# Altium schematic sheet 20180616.SchDoc
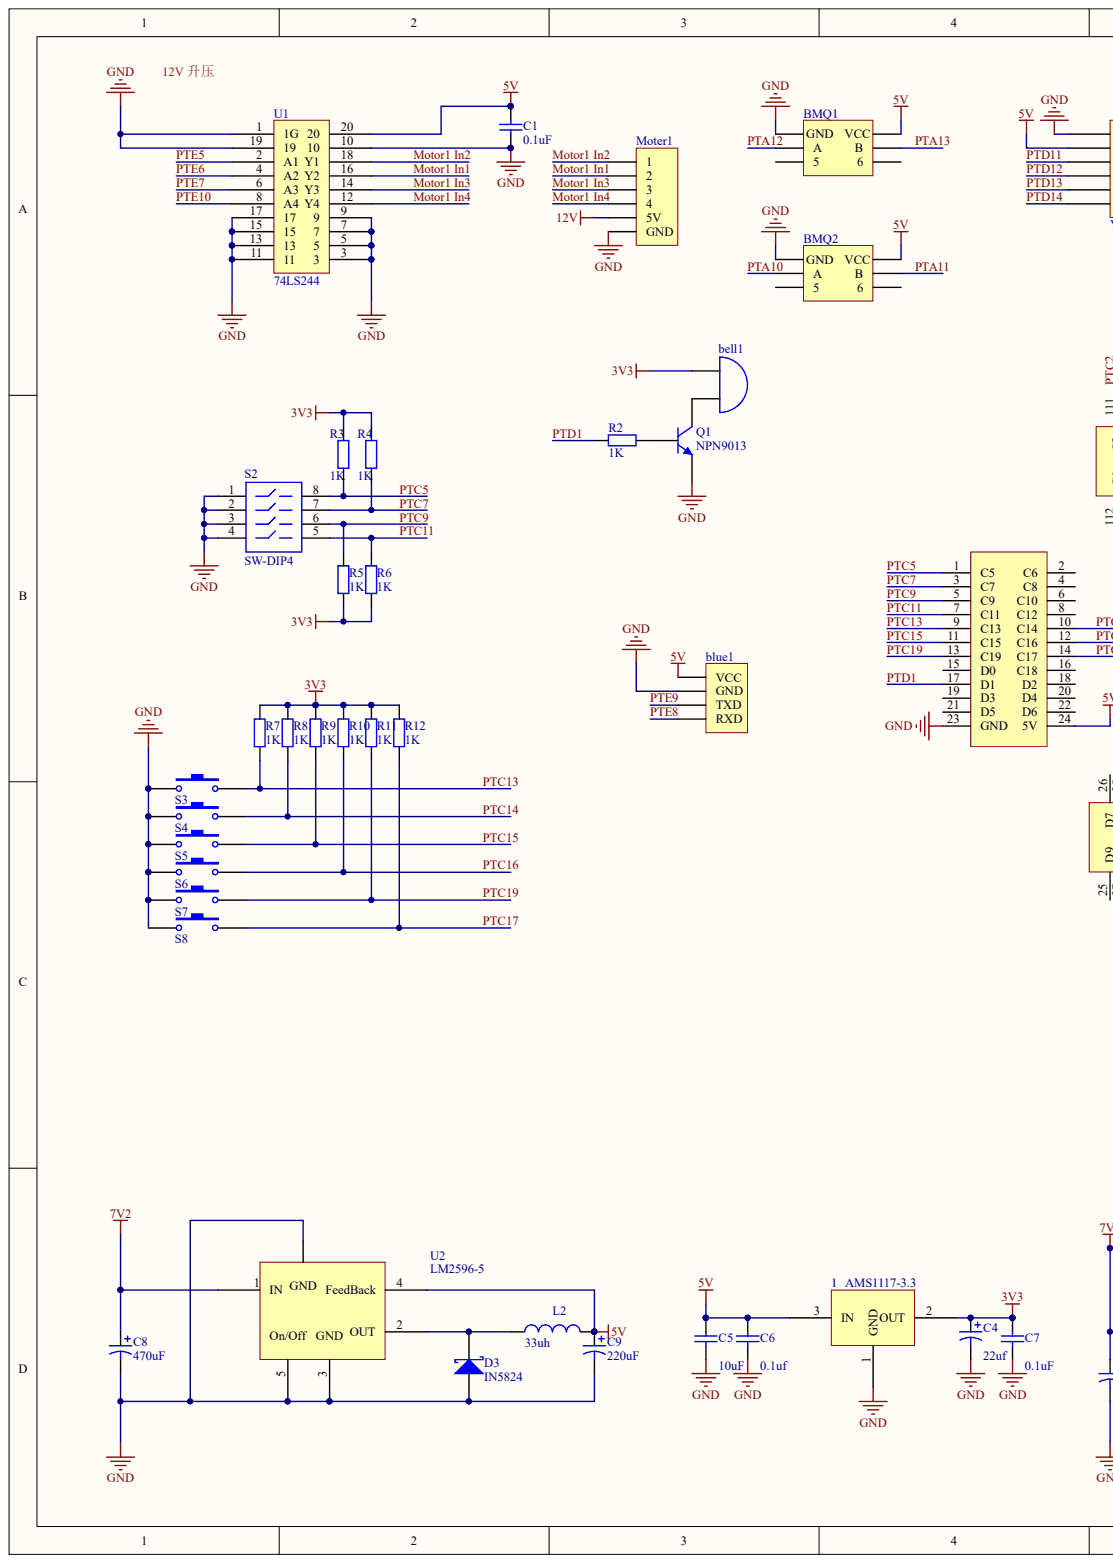
[diagram p0: 20180616.SchDoc, left]
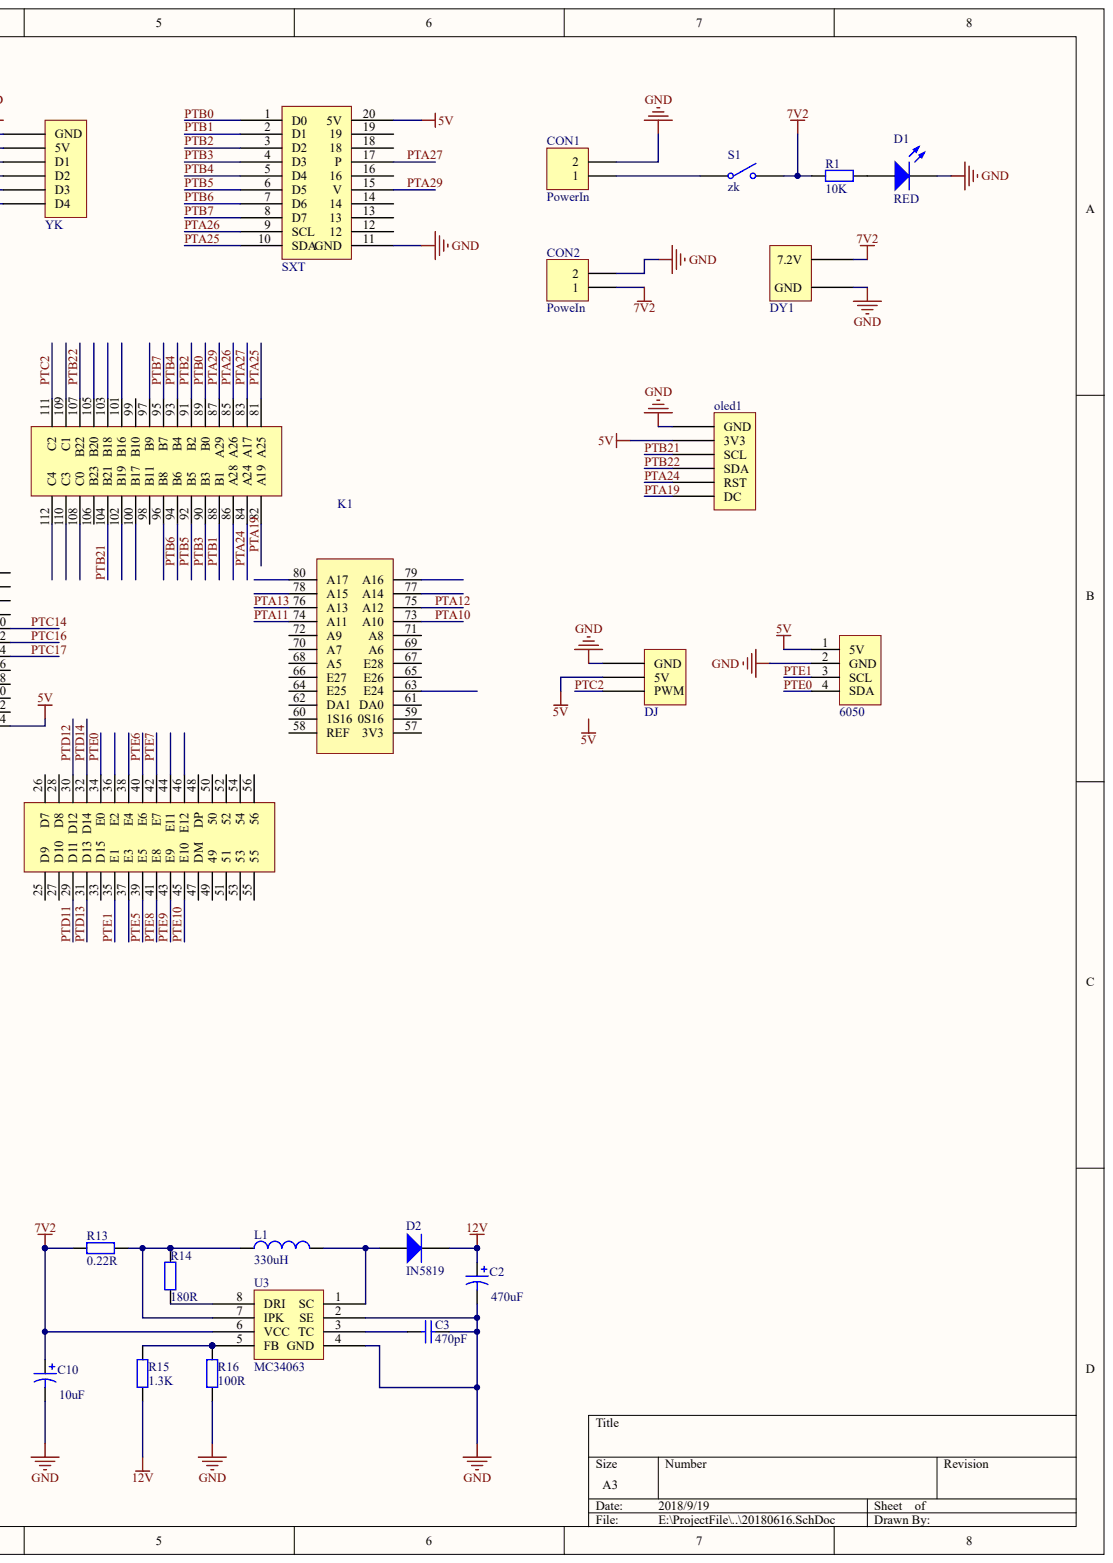
[diagram p1: 20180616.SchDoc, right]

## Parts
1  AMS1117-3.3
*  6050
*1  SXT
*2  YK
*7  DJ
bell1  Bell  (value not drawn)
blue1  blueteeth2  (value not drawn)
BMQ1  encode_1  (value not drawn)
BMQ2  encode_2  (value not drawn)
C1  0.1uF
C2  470uF
C3  470pF
C4  value 22uf  (hidden comment: Cap Pol1)
C5  value 10uF  (hidden comment: Cap)
C6  value 0.1uf  (hidden comment: Cap)
C7  value 0.1uF  (hidden comment: Cap)
C8  value 470uF  (hidden comment: Cap Pol1)
C9  value 220uF  (hidden comment: Cap Pol1)
C10  10uF
CON1  PowerIn
CON2  PoweIn
D1  RED
D2  IN5819
D3  IN5824
DY1  H1  (value not drawn)
K1  K60  (value not drawn)
L1  330uH
L2  value 33uh  (hidden comment: Inductor)
Moter1  Motor
oled1  OLED  (value not drawn)
Q1  NPN9013
R1  value 10K  (hidden comment: Res2)
R2  value 1K  (hidden comment: Res2)
R3  value 1K  (hidden comment: Res2)
R4  value 1K  (hidden comment: Res2)
R5  value 1K  (hidden comment: Res2)
R6  value 1K  (hidden comment: Res2)
R7  value 1K  (hidden comment: Res2)
R8  value 1K  (hidden comment: Res2)
R9  value 1K  (hidden comment: Res2)
R10  value 1K  (hidden comment: Res2)
R11  value 1K  (hidden comment: Res2)
R12  value 1K  (hidden comment: Res2)
R13  0.22R
R14  180R
R15  1.3K
R16  100R
S1  zk
S2  SW-DIP4
S3  SW-PB  (value not drawn)
S4  SW-PB  (value not drawn)
S5  SW-PB  (value not drawn)
S6  SW-PB  (value not drawn)
S7  SW-PB  (value not drawn)
S8  SW-PB  (value not drawn)
U1  74LS244
U2  LM2596-5
U3  MC34063

## Nets
3V3: 1.2 bell1.1 C4.1 C7.2 R3.2 R4.2 R5.2 R6.1 R7.2 R8.2 R9.2 R10.2 R11.2 R12.2
5V: 1.3 *.1(5V) *1.20(5V) *2.2(5V) *7.2 blue1.1(VCC) BMQ1.2(VCC) BMQ2.2(VCC) C1.2 C5.2 C6.2 C9.1 K1.24 L2.2 oled1.2(3V3) U1.20 U2.4(FeedBack)
7V2: C8.1 C10.1 CON2.1 DY1.2 R1.2 R13.2 S1.2 U2.1(IN) U3.6(VCC)
12V: C2.1 D2.2 Moter1.5(5V) R15.2
GND: 1.1 *.2(GND) *1.11(GND) *2.1(GND) *7.1 blue1.2(GND) BMQ1.1(GND) BMQ2.1(GND) C1.1 C2.2 C3.2 C4.2 C5.1 C6.1 C7.1 C8.2 C9.2 C10.2 CON1.2 CON2.2 D1.2 D3.2 DY1.1 K1.23(GND) Moter1.6(GND) oled1.1(GND) Q1.1 R16.2 S2.1 S2.2 S2.3 S2.4 S3.1 S4.1 S5.1 S6.1 S7.1 S8.1 U1.1(1G) U1.3 U1.5 U1.7 U1.9 U1.10 U1.11 U1.13 U1.15 U1.17 U1.19 U2.3 U2.5 U2.6 U3.2(SE) U3.4(GND)
MOTOR1 IN1: Moter1.2 U1.16(Y2)
MOTOR1 IN2: Moter1.1 U1.18(Y1)
MOTOR1 IN3: Moter1.3 U1.14(Y3)
MOTOR1 IN4: Moter1.4 U1.12(Y4)
PTA10: BMQ2.3(A) K1.73(A10)
PTA11: BMQ2.4(B) K1.74
PTA12: BMQ1.3(A) K1.75(A12)
PTA13: BMQ1.4(B) K1.76
PTA19: K1.82 oled1.6(DC)
PTA24: K1.84 oled1.5(RST)
PTA25: *1.10(SDA) K1.81(A25)
PTA26: *1.9(SCL) K1.85(A26)
PTA27: *1.17(P) K1.83(A17)
PTA29: *1.15(V) K1.87(A29)
PTB0: *1.1(D0) K1.89(B0)
PTB1: *1.2(D1) K1.88
PTB2: *1.3(D2) K1.91(B2)
PTB3: *1.4(D3) K1.90
PTB4: *1.5(D4) K1.93(B4)
PTB5: *1.6(D5) K1.92
PTB6: *1.7(D6) K1.94
PTB7: *1.8(D7) K1.95(B7)
PTB21: K1.104 oled1.3(SCL)
PTB22: K1.107(B22) oled1.4(SDA)
PTC2: *7.3 K1.111(C2)
PTC5: K1.1(C5) R3.1 S2.8
PTC7: K1.3(C7) R4.1 S2.7
PTC9: K1.5(C9) R5.1 S2.6
PTC11: K1.7(C11) R6.2 S2.5
PTC13: K1.9(C13) R7.1 S3.2
PTC14: K1.10 R8.1 S4.2
PTC15: K1.11(C15) R9.1 S5.2
PTC16: K1.12 R10.1 S6.2
PTC17: K1.14 R12.1 S8.2
PTC19: K1.13(C19) R11.1 S7.2
PTD1: K1.17(D1) R2.1
PTD11: *2.3(D1) K1.29(D11)
PTD12: *2.4(D2) K1.30
PTD13: *2.5(D3) K1.31(D13)
PTD14: *2.6(D4) K1.32
PTE0: *.4(SDA) K1.34
PTE1: *.3(SCL) K1.35(E1)
PTE5: K1.39(E5) U1.2(A1)
PTE6: K1.40 U1.4(A2)
PTE7: K1.42 U1.6(A3)
PTE8: blue1.4(RXD) K1.41(E8)
PTE9: blue1.3(TXD) K1.43(E9)
PTE10: K1.45(E10) U1.8(A4)
Netbell1_2: bell1.2 Q1.3
NetC3_1: C3.1 U3.3(TC)
NetCON1_1: CON1.1 S1.1
NetD1_1: D1.1 R1.1
NetD2_1: D2.1 L1.2 U3.1(SC)
NetD3_1: D3.1 L2.1 U2.2(OUT)
NetL1_1: L1.1 R13.1 R14.2 U3.7(IPK)
NetQ1_2: Q1.2 R2.2
NetR14_1: R14.1 U3.8(DRI)
NetR15_1: R15.1 R16.1 U3.5(FB)

Not connected: *1 12 13 14 16 18 19; BMQ1 5 6; BMQ2 5 6; K1 2 4 6 8 15(D0) 16 18 19(D3) 20 21(D5) 22 25(D9) 26 27(D10) 28 33(D15) 36 37(E3) 38 44 46 47(DM) 48 49 50 51 52 53 54 55 56 57(3V3) 58 59(0S16) 60 61(DA0) 62 63(E24) 64 65(E26) 66 67(E28) 68 69(A6) 70 71(A8) 72 77(A14) 78 79(A16) 80 86 96 97(B9) 98 99(B10) 100 101(B16) 102 103(B18) 105(B20) 106 108 109(C1) 110 112

## Library data of the parts
L1 L2 = (no symbol name): Code_IEC: 1005; Published: 8-Jun-2000; LatestRevisionDate: 29-May-2009; LatestRevisionNote: IPC-7351 Footprint Added.; PackageReference: 0402-A; PackageDescription: Chip Inductor; Code_JEITA: 0402; Publisher: Altium Limited
  L1: Value: 330uH
1 * *1 *2 *7 bell1 blue1 BMQ1 BMQ2 C1-C10 CON1 CON2 D1-D3 DY1 K1 Moter1 oled1 Q1 R1-R16 S1-S8 U1-U3 = (no symbol name)
  bell1: Published: 24-Mar-1999; LatestRevisionDate: 17-Jul-2002; LatestRevisionNote: Re-released for DXP Platform.; PackageReference: PIN2
  C1: Published: 8-Jun-2000; LatestRevisionDate: 29-May-2009; LatestRevisionNote: IPC-7351 Footprint Added.; PackageReference: C1206; PackageDescription: Chip Capacitor; Value: 0.1uF
  C2: Published: 8-Jun-2000; LatestRevisionDate: 29-May-2009; LatestRevisionNote: IPC-7351 Footprint Added.; PackageReference: C0805; PackageDescription: Chip Capacitor; Value: 470uF
  C3: Published: 8-Jun-2000; LatestRevisionDate: 29-May-2009; LatestRevisionNote: IPC-7351 Footprint Added.; PackageReference: C1206; PackageDescription: Chip Capacitor; Value: 470pF
  C4 C8 C9: Published: 8-Jun-2000; LatestRevisionDate: 17-Jul-2002; LatestRevisionNote: Re-released for DXP Platform.; PackageReference: RB7.6-15; PackageDescription: Polarized Capacitor (Radial); 2 Leads
  C5-C7: Published: 8-Jun-2000; LatestRevisionDate: 17-Jul-2002; LatestRevisionNote: Re-released for DXP Platform.; PackageReference: RAD-0.3; PackageDescription: Radial Cap, Thru-Hole; 2 Leads; 0.3 in Pin Spacing
  C10: Published: 8-Jun-2000; LatestRevisionDate: 29-May-2009; LatestRevisionNote: IPC-7351 Footprint Added.; PackageReference: C0805; PackageDescription: Chip Capacitor; Value: 10uF
  CON1 CON2: LatestRevisionDate: 17-Jul-2002; LatestRevisionNote: Re-released for DXP Platform.
  D1: Published: 8-Jun-2000; LatestRevisionDate: 17-Jul-2002; LatestRevisionNote: Re-released for DXP Platform.; PackageVersion: Feb-2002; PackageReference: 3.2X1.6X1.1; PackageDescription: SM LED; 2 Flat Leads
  D2: Published: 8-Jun-2000; LatestRevisionDate: 17-Jul-2002; LatestRevisionNote: Re-released for DXP Platform.; PackageVersion: Sep-1996; PackageReference: SMC; PackageDescription: DO-214-AB/SMC; 2 C-Bend Leads; Body 7.9 x 5.9 mm, inc. leads (LxW); Code_JEDEC: DO-214-AB
  Q1: Published: 8-Jun-2000; LatestRevisionDate: 15-Jan-2003; LatestRevisionNote: PCB Footprint 'BCY-W3/D4.7' replaced; DatasheetVersion: 1997; PackageVersion: Sep-1998; PackageReference: TO-92A; PackageDescription: TO, Flat Index; 3 In-Line, Axial Leads; Body Dia. 4.5mm; Leads 0.51 x 0.48 mm (max); ComponentLink1Description: Manufacturer Link; ComponentLink1URL: http://www.fairchildsemi.com/; ComponentLink2Description: Datasheet; ComponentLink2URL: http://www.fairchildsemi.com/ds/2N%2F2N3904.pdf
  R1-R12: Published: 8-Jun-2000; LatestRevisionDate: 17-Jul-2002; LatestRevisionNote: Re-released for DXP Platform.; PackageReference: AXIAL-0.4; PackageDescription: Axial Device, Thru-Hole; 2 Leads; 0.4 in Pin Spacing
  R13: Published: 8-Jun-2000; LatestRevisionDate: 17-Jul-2002; LatestRevisionNote: Re-released for DXP Platform.; PackageReference: AXIAL-0.4; PackageDescription: Axial Device, Thru-Hole; 2 Leads; 0.4 in Pin Spacing; Value: 0.22R
  R14: Published: 8-Jun-2000; LatestRevisionDate: 17-Jul-2002; LatestRevisionNote: Re-released for DXP Platform.; PackageReference: AXIAL-0.4; PackageDescription: Axial Device, Thru-Hole; 2 Leads; 0.4 in Pin Spacing; Value: 180R
  R15: Published: 8-Jun-2000; LatestRevisionDate: 17-Jul-2002; LatestRevisionNote: Re-released for DXP Platform.; PackageReference: AXIAL-0.4; PackageDescription: Axial Device, Thru-Hole; 2 Leads; 0.4 in Pin Spacing; Value: 1.3K
  R16: Published: 8-Jun-2000; LatestRevisionDate: 17-Jul-2002; LatestRevisionNote: Re-released for DXP Platform.; PackageReference: AXIAL-0.4; PackageDescription: Axial Device, Thru-Hole; 2 Leads; 0.4 in Pin Spacing; Value: 100R
  S1 S3-S8: Published: 24-Mar-1999; LatestRevisionDate: 17-Jul-2002; LatestRevisionNote: Re-released for DXP Platform.; PackageReference: SPST-2; PackageDescription: Switch; 2 Leads
  S2: Published: 24-Mar-1999; LatestRevisionDate: 17-Jul-2002; LatestRevisionNote: Re-released for DXP Platform.; PackageReference: DIP-8
  bell1 C1-C10 CON1 CON2 D1 D2 Q1 R1-R16 S1-S8: Publisher: Altium Limited
